# Altium schematic sheet JGL1905_Xyloni_GPIO&Expansion_V1.06.SchDoc
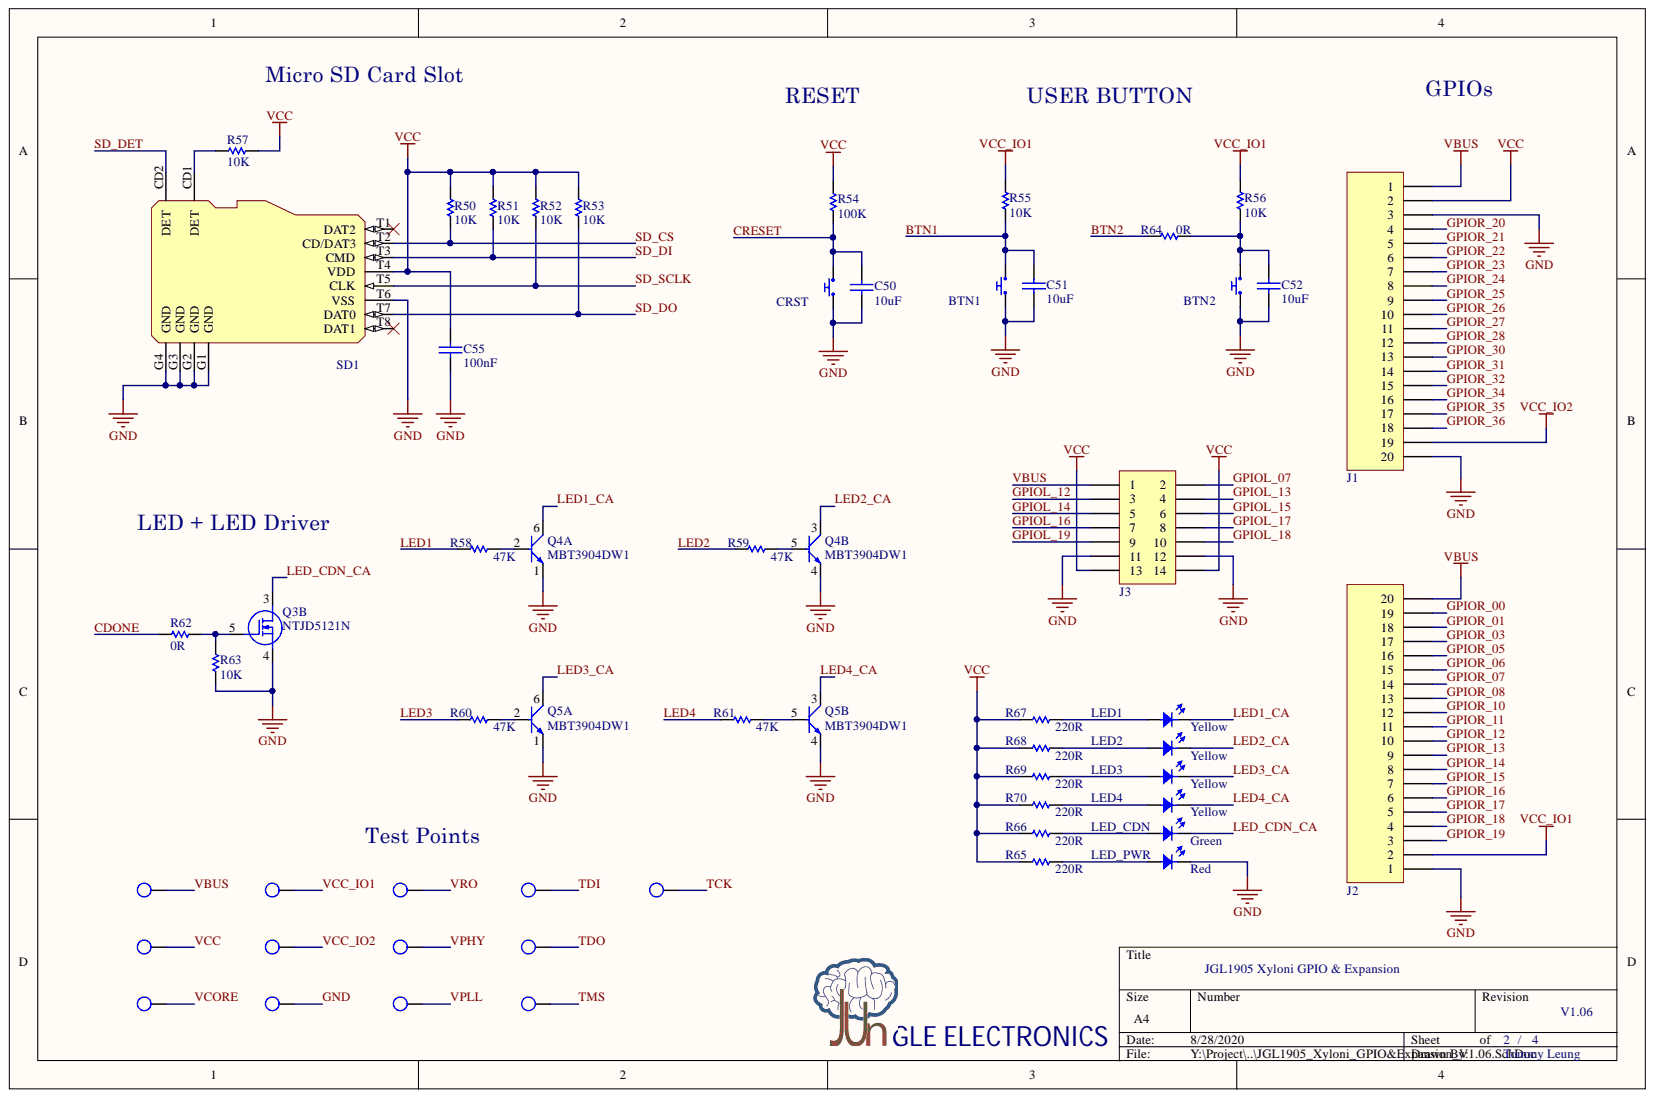
[diagram p0: JGL1905_Xyloni_GPIO&Expansion_V1.06.SchDoc]

## Parts
BTN1  SWITCH_SPST_PUSHON  [PTS820 J20]  (value not drawn)
BTN2  SWITCH_SPST_PUSHON  [PTS820 J20]  (value not drawn)
C50  value 10uF  [CAPC0402M]  (hidden comment: CAPACITOR)
C51  value 10uF  [CAPC0402M]  (hidden comment: CAPACITOR)
C52  value 10uF  [CAPC0402M]  (hidden comment: CAPACITOR)
C55  value 100nF  [CAPC0402M]  (hidden comment: CAPACITOR)
CRST  SWITCH_SPST_PUSHON  [PTS820 J20]  (value not drawn)
GND  TEST_POINT  [TP-SMD]  (value not drawn)
J1  Header  [PHM-1R20P-2M54]  (value not drawn)
J2  Header  [PHM-1R20P-2M54]  (value not drawn)
J3  Header  [PHM-2R7P-2M54]  (value not drawn)
LED1  LED  value Yellow  [LED0603]
LED2  LED  value Yellow  [LED0603]
LED3  LED  value Yellow  [LED0603]
LED4  LED  value Yellow  [LED0603]
LED_CDN  LED  value Green  [LED0603]
LED_PWR  LED  value Red  [LED0603]
Q3  NTJD5121N  [SOT-363]  (part B)
Q4  MBT3904DW1  [SOT-363]  (parts A,B)
Q5  MBT3904DW1  [SOT-363]  (parts A,B)
R50  value 10K  [RESC0402M]  (hidden comment: RESISTOR)
R51  value 10K  [RESC0402M]  (hidden comment: RESISTOR)
R52  value 10K  [RESC0402M]  (hidden comment: RESISTOR)
R53  value 10K  [RESC0402M]  (hidden comment: RESISTOR)
R54  value 100K  [RESC0402M]  (hidden comment: RESISTOR)
R55  value 10K  [RESC0402M]  (hidden comment: RESISTOR)
R56  value 10K  [RESC0402M]  (hidden comment: RESISTOR)
R57  value 10K  [RESC0402M]  (hidden comment: RESISTOR)
R58  value 47K  [RESC0402M]  (hidden comment: RESISTOR)
R59  value 47K  [RESC0402M]  (hidden comment: RESISTOR)
R60  value 47K  [RESC0402M]  (hidden comment: RESISTOR)
R61  value 47K  [RESC0402M]  (hidden comment: RESISTOR)
R62  value 0R  [RESC0402M]  (hidden comment: RESISTOR)
R63  value 10K  [RESC0402M]  (hidden comment: RESISTOR)
R64  value 0R  [RESC0402M]  (hidden comment: RESISTOR)
R65  value 220R  [RESC0402M]  (hidden comment: RESISTOR)
R66  value 220R  [RESC0402M]  (hidden comment: RESISTOR)
R67  value 220R  [RESC0402M]  (hidden comment: RESISTOR)
R68  value 220R  [RESC0402M]  (hidden comment: RESISTOR)
R69  value 220R  [RESC0402M]  (hidden comment: RESISTOR)
R70  value 220R  [RESC0402M]  (hidden comment: RESISTOR)
SD1  MICRO_SD_CON  [104031-0811]  (value not drawn)
TCK  TEST_POINT  [TP-SMD]  (value not drawn)
TDI  TEST_POINT  [TP-SMD]  (value not drawn)
TDO  TEST_POINT  [TP-SMD]  (value not drawn)
TMS  TEST_POINT  [TP-SMD]  (value not drawn)
VBUS  TEST_POINT  [TP-SMD]  (value not drawn)
VCC  TEST_POINT  [TP-SMD]  (value not drawn)
VCC_IO1  TEST_POINT  [TP-SMD]  (value not drawn)
VCC_IO2  TEST_POINT  [TP-SMD]  (value not drawn)
VCORE  TEST_POINT  [TP-SMD]  (value not drawn)
VPHY  TEST_POINT  [TP-SMD]  (value not drawn)
VPLL  TEST_POINT  [TP-SMD]  (value not drawn)
VRO  TEST_POINT  [TP-SMD]  (value not drawn)

## Nets
BTN1: BTN1.2 C51.1 R55.2
BTN2: R64.2
CDONE: R62.1
CRESET: C50.1 CRST.2 R54.2
GND: BTN1.1 BTN1.3 BTN2.1 BTN2.3 C50.2 C51.2 C52.2 C55.1 CRST.1 CRST.3 GND.1 J1.3 J1.20 J2.1 J3.11 J3.12 LED_PWR.2 Q3.4 Q4.1 Q4.4 Q5.1 Q5.4 R63.1 SD1.G1(GND) SD1.G2(GND) SD1.G3(GND) SD1.G4(GND) SD1.T6(VSS)
GPIOL_07: J3.2
GPIOL_12: J3.3
GPIOL_13: J3.4
GPIOL_14: J3.5
GPIOL_15: J3.6
GPIOL_16: J3.7
GPIOL_17: J3.8
GPIOL_18: J3.10
GPIOL_19: J3.9
GPIOR_00: J2.19
GPIOR_01: J2.18
GPIOR_03: J2.17
GPIOR_05: J2.16
GPIOR_06: J2.15
GPIOR_07: J2.14
GPIOR_08: J2.13
GPIOR_10: J2.12
GPIOR_11: J2.11
GPIOR_12: J2.10
GPIOR_13: J2.9
GPIOR_14: J2.8
GPIOR_15: J2.7
GPIOR_16: J2.6
GPIOR_17: J2.5
GPIOR_18: J2.4
GPIOR_19: J2.3
GPIOR_20: J1.4
GPIOR_21: J1.5
GPIOR_22: J1.6
GPIOR_23: J1.7
GPIOR_24: J1.8
GPIOR_25: J1.9
GPIOR_26: J1.10
GPIOR_27: J1.11
GPIOR_28: J1.12
GPIOR_30: J1.13
GPIOR_31: J1.14
GPIOR_32: J1.15
GPIOR_34: J1.16
GPIOR_35: J1.17
GPIOR_36: J1.18
LED1: R58.2
LED1_CA: LED1.2 Q4.6
LED2: R59.2
LED2_CA: LED2.2 Q4.3
LED3: R60.2
LED3_CA: LED3.2 Q5.6
LED4: R61.2
LED4_CA: LED4.2 Q5.3
LED_CDN_CA: LED_CDN.2 Q3.3
SD_CS: R50.1 SD1.T2(CD/DAT3)
SD_DET: SD1.CD2(DET)
SD_DI: R51.1 SD1.T3(CMD)
SD_DO: R53.1 SD1.T7(DAT0)
SD_SCLK: R52.1 SD1.T5(CLK)
TCK: TCK.1
TDI: TDI.1
TDO: TDO.1
TMS: TMS.1
VBUS: J1.1 J2.20 J3.1 VBUS.1
VCC: C55.2 J1.2 J3.13 J3.14 R50.2 R51.2 R52.2 R53.2 R54.1 R57.1 R65.2 R66.2 R67.2 R68.2 R69.2 R70.2 SD1.T4(VDD) VCC.1
VCC_IO1: J2.2 R55.1 R56.1 VCC_IO1.1
VCC_IO2: J1.19 VCC_IO2.1
VCORE: VCORE.1
VPHY: VPHY.1
VPLL: VPLL.1
VRO: VRO.1
NetBTN2_2: BTN2.2 C52.1 R56.2 R64.1
NetLED1_1: LED1.1 R67.1
NetLED2_1: LED2.1 R68.1
NetLED3_1: LED3.1 R69.1
NetLED4_1: LED4.1 R70.1
NetLED_CDN_1: LED_CDN.1 R66.1
NetLED_PWR_1: LED_PWR.1 R65.1
NetQ3_5: Q3.5 R62.2 R63.2
NetQ4_2: Q4.2 R58.1
NetQ4_5: Q4.5 R59.1
NetQ5_2: Q5.2 R60.1
NetQ5_5: Q5.5 R61.1
NetR57_2: R57.2 SD1.CD1(DET)

Not connected: SD1 T1(DAT2) T8(DAT1)

## Library data of the parts
BTN1 BTN2 CRST = SWITCH_SPST_PUSHON: Single Pole Single Throw Push-ON Switch; Mfr. PN: PTS820J20MSMTR; Component Kind: Standard; Supplier 1: Mouser; Supplier Part Number 1: 611-PTS820J20MSMTR; Library Name: Jungle_ADLibrary.IntLib
C50-C52 = CAPACITOR: Mfr. PN: GRM155C80J106ME1J; Component Kind: Standard; Description: Capacitor; Supplier 1: Mouser; Supplier Part Number 1: 81-GRM155C80J106ME1J; Library Name: Jungle_ADLibrary.IntLib
C55 = CAPACITOR: Mfr. PN: EMK105B7104KV-F; Component Kind: Standard; Description: Capacitor; Supplier 1: Mouser; Supplier Part Number 1: 963-EMK105B7104KV-F; Library Name: Jungle_ADLibrary.IntLib
GND TCK TDI TDO TMS VBUS VCC VCC_IO1 VCC_IO2 VCORE VPHY VPLL VRO = TEST_POINT: Test Point; Component Kind: Standard; Library Name: Jungle_ADLibrary.IntLib
J1 J2 = PH_1R20P: Pin Header 1 Row 20 Pin; Mfr. PN: 61302011121; Component Kind: Standard; Supplier 1: Mouser; Supplier Part Number 1: 710-61302011121; Library Name: Jungle_ADLibrary.IntLib
J3 = PH_2R7P: Pin Header 2 Row 7 Pin; Mfr. PN: 613012243121; Component Kind: Standard; Library Name: Jungle_ADLibrary.IntLib
LED1-LED4 = LED: Light Emitter Diode; Mfr. PN: LTST-C191KSKT; Component Kind: Standard; Supplier 1: Mouser; Supplier Part Number 1: 859-LTST-C191KSKT; Library Name: Jungle_ADLibrary.IntLib
LED_CDN = LED: Light Emitter Diode; Mfr. PN: LTST-C191KGKT; Component Kind: Standard; Supplier 1: Mouser; Supplier Part Number 1: 859-LTST-C191KGKT; Library Name: Jungle_ADLibrary.IntLib
LED_PWR = LED: Light Emitter Diode; Mfr. PN: LTST-C191KRKT; Component Kind: Standard; Supplier 1: Mouser; Supplier Part Number 1: 859-LTST-C191KRKT; Library Name: Jungle_ADLibrary.IntLib
Q3 = NTJD5121N: MOSFET – Power, Dual,  N-Channel With ESD  Protection, SC-88  60 V, 295 mA; Component Kind: Standard; Mfr. PN: NTJD5121N; Supplier 1: Mouser; Supplier Part Number 1: 863-NTJD5121NT1G; Value: NTJD5121N; Library Name: Jungle_ADLibrary.IntLib
Q4 Q5 = MBT3904DW1: Dual General Purpose  Transistors, SC-88, hFE 100-300  40 V, 200 mA; Component Kind: Standard; Mfr. PN: MBT3904DW1; Supplier 1: Mouser; Supplier Part Number 1: 863-MBT3904DW1T1G; Value: MBT3904DW1; Library Name: Jungle_ADLibrary.IntLib
R50-R53 R55-R57 R63 = RESISTOR: Mfr. PN: RC0402FR-0710KL; Component Kind: Standard; Description: Resistor; Supplier 1: Mouser; Supplier Part Number 1: 603-RC0402FR-0710KL; Library Name: Jungle_ADLibrary.IntLib
R54 = RESISTOR: Mfr. PN: RC0402FR-07100KL; Component Kind: Standard; Description: Resistor; Supplier 1: Mouser; Supplier Part Number 1: 603-RC0402FR-07100KL; Library Name: Jungle_ADLibrary.IntLib
R58-R61 = RESISTOR: Mfr. PN: RC0402FR-0747KL; Component Kind: Standard; Description: Resistor; Supplier 1: Mouser; Supplier Part Number 1: 603-RC0402FR-0747KL; Library Name: Jungle_ADLibrary.IntLib
R62 R64 = RESISTOR: Mfr. PN: RC0402FR-070RL; Component Kind: Standard; Description: Resistor; Supplier 1: Mouser; Supplier Part Number 1: 603-RC0402FR-070RL; Library Name: Jungle_ADLibrary.IntLib
R65-R70 = RESISTOR: Mfr. PN: RC0402FR-07220RL; Component Kind: Standard; Description: Resistor; Supplier 1: Mouser; Supplier Part Number 1: 603-RC0402FR-07220RL; Library Name: Jungle_ADLibrary.IntLib
SD1 = SD_CON_MICRO_DETECT: 1.10mm Pitch microSD Card Header with Detect Switch; Component Kind: Standard; Mfr. PN: 104031-0811; Supplier 1: Mouser; Supplier Part Number 1: 538-104031-0811; Library Name: Jungle_ADLibrary.IntLib
